# Altium schematic sheet 3-USB_to_JTAG.schdoc(3-USB_to_JTAG)
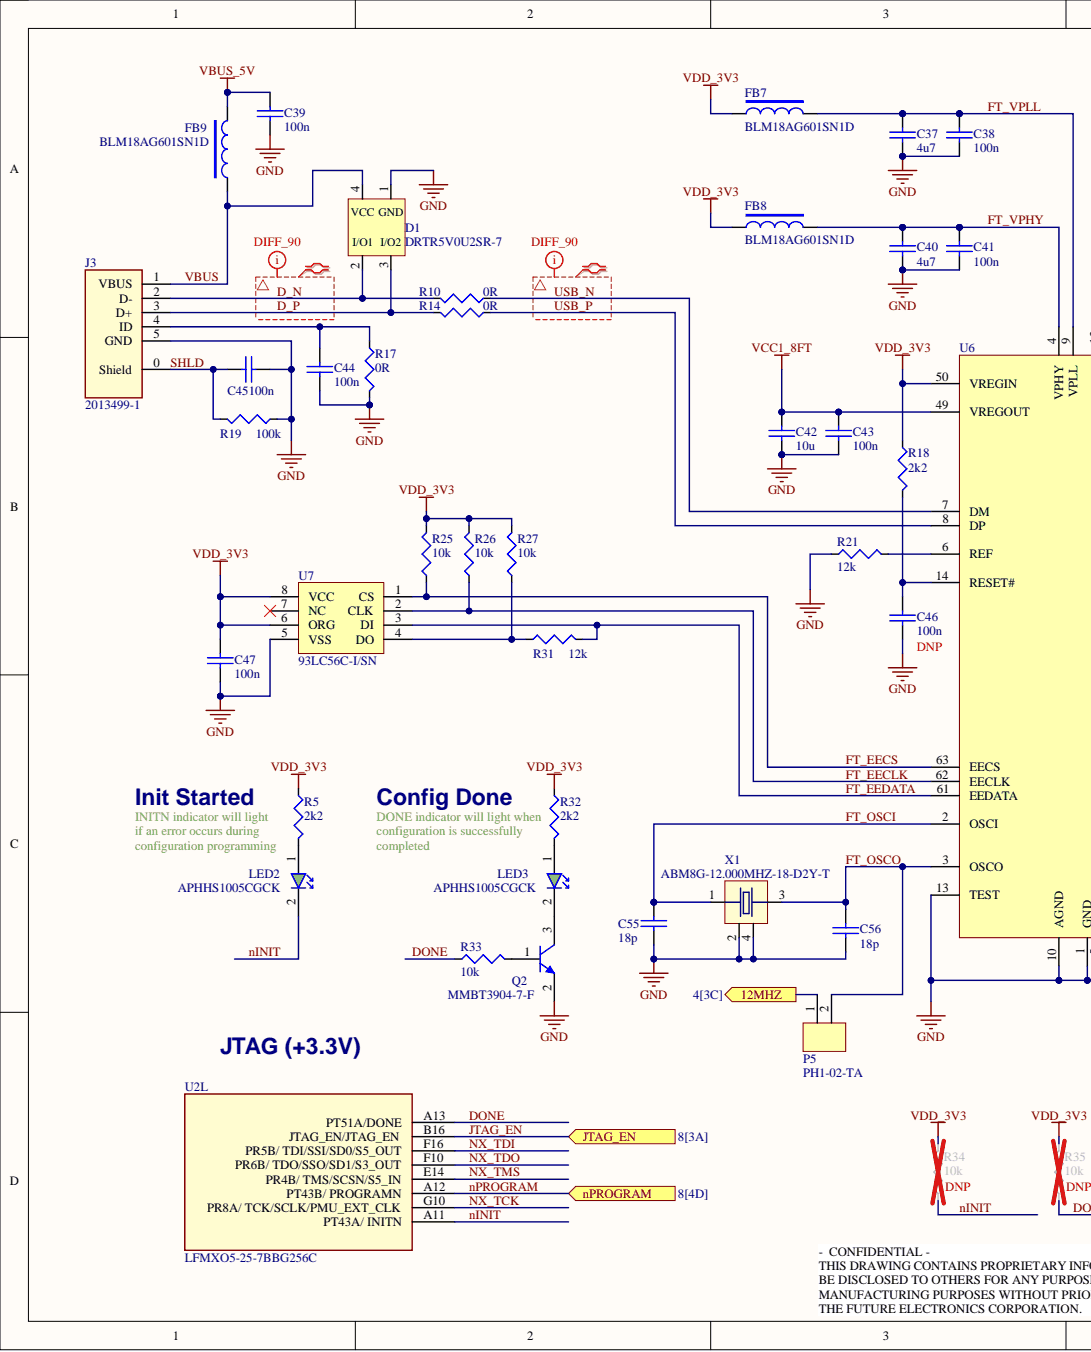
[diagram p0: 3-USB_to_JTAG.schdoc, left]
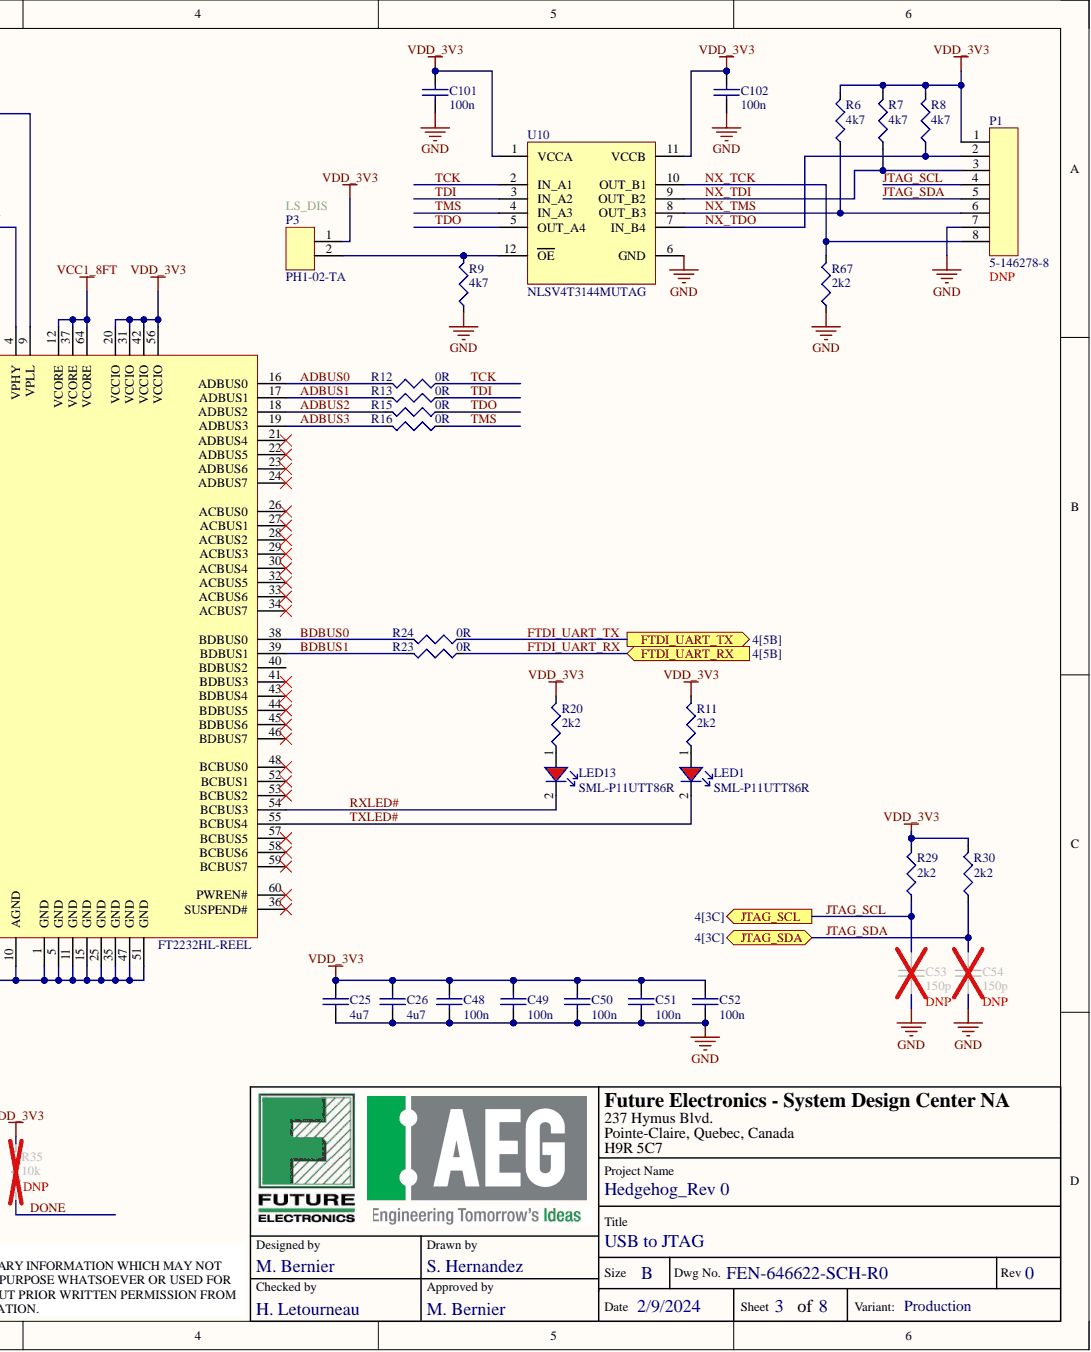
[diagram p1: 3-USB_to_JTAG.schdoc, right]

## Parts
C25  value 4u7  [0805]  (hidden comment: C-4.7uF-10V-Cer-0805)
C26  value 4u7  [0805]  (hidden comment: C-4.7uF-10V-Cer-0805)
C37  value 4u7  [0805]  (hidden comment: C-4.7uF-10V-Cer-0805)
C38  value 100n  [0402]  (hidden comment: C-100nF-50V-Cer-0402)
C39  value 100n  [0402]  (hidden comment: C-100nF-50V-Cer-0402)
C40  value 4u7  [0805]  (hidden comment: C-4.7uF-10V-Cer-0805)
C41  value 100n  [0402]  (hidden comment: C-100nF-50V-Cer-0402)
C42  value 10u  [1206]  (hidden comment: C-10uF-50V-Cer-1206_A)
C43  value 100n  [0402]  (hidden comment: C-100nF-50V-Cer-0402)
C44  value 100n  [0402]  (hidden comment: C-100nF-50V-Cer-0402)
C45  value 100n  [0402]  (hidden comment: C-100nF-50V-Cer-0402)
C46  value 100n  [0402]  (hidden comment: C-100nF-50V-Cer-0402)
C47  value 100n  [0402]  (hidden comment: C-100nF-50V-Cer-0402)
C48  value 100n  [0402]  (hidden comment: C-100nF-50V-Cer-0402)
C49  value 100n  [0402]  (hidden comment: C-100nF-50V-Cer-0402)
C50  value 100n  [0402]  (hidden comment: C-100nF-50V-Cer-0402)
C51  value 100n  [0402]  (hidden comment: C-100nF-50V-Cer-0402)
C52  value 100n  [0402]  (hidden comment: C-100nF-50V-Cer-0402)
C53  value 150p  [0402]  (hidden comment: C-150pF-25V-Cer-0402)
C54  value 150p  [0402]  (hidden comment: C-150pF-25V-Cer-0402)
C55  value 18p  [0402]  (hidden comment: C-18pF-25V-Cer-0402)
C56  value 18p  [0402]  (hidden comment: C-18pF-25V-Cer-0402)
C101  value 100n  [0402]  (hidden comment: C-100nF-50V-Cer-0402)
C102  value 100n  [0402]  (hidden comment: C-100nF-50V-Cer-0402)
D1  MFR PN: DRTR5V0U2SR-7  [SOT143]  (hidden comment: D-DRTR5V0U2SR)
FB7  MFR PN: BLM18AG601SN1D  [0603]  (hidden comment: FB-600Ohm@100MHz-0603)
FB8  MFR PN: BLM18AG601SN1D  [0603]  (hidden comment: FB-600Ohm@100MHz-0603)
FB9  MFR PN: BLM18AG601SN1D  [0603]  (hidden comment: FB-600Ohm@100MHz-0603)
J3  Future PN (TR): 2013499-1  (hidden comment: J-2013499-1)
LED1  Future PN (TR): SML-P11UTT86R  [0402_LED_RED]  (hidden comment: LED-SML-P11UTT86R)
LED2  Future PN (TR): APHHS1005CGCK  [0402-Kingbright]  (hidden comment: LED-APHHS1005CGCK)
LED3  Future PN (TR): APHHS1005CGCK  [0402-Kingbright]  (hidden comment: LED-APHHS1005CGCK)
LED13  Future PN (TR): SML-P11UTT86R  [0402_LED_RED]  (hidden comment: LED-SML-P11UTT86R)
P1  P-5-146278-8  MFR PN: 5-146278-8  [HDR100-8PIN-TH]
P3  MFR PN: PH1-02-TA  [HDR100-2PIN-TH]  (hidden comment: P-PH1-02-TA)
P5  MFR PN: PH1-02-TA  [HDR100-2PIN-TH]  (hidden comment: P-PH1-02-TA)
Q2  Future PN (TR): MMBT3904-7-F  [SOT23]  (hidden comment: Q-MMBT3904-7-F)
R5  value 2k2  [0402]  (hidden comment: R-2.2k-63mW-0402)
R6  value 4k7  [0402]  (hidden comment: R-4.7k-63mW-0402)
R7  value 4k7  [0402]  (hidden comment: R-4.7k-63mW-0402)
R8  value 4k7  [0402]  (hidden comment: R-4.7k-63mW-0402)
R9  value 4k7  [0402]  (hidden comment: R-4.7k-63mW-0402)
R10  value 0R  [0402]  (hidden comment: R-0R-63mW-0402)
R11  value 2k2  [0402]  (hidden comment: R-2.2k-63mW-0402)
R12  value 0R  [0402]  (hidden comment: R-0R-63mW-0402)
R13  value 0R  [0402]  (hidden comment: R-0R-63mW-0402)
R14  value 0R  [0402]  (hidden comment: R-0R-63mW-0402)
R15  value 0R  [0402]  (hidden comment: R-0R-63mW-0402)
R16  value 0R  [0402]  (hidden comment: R-0R-63mW-0402)
R17  value 0R  [0402]  (hidden comment: R-0R-63mW-0402)
R18  value 2k2  [0402]  (hidden comment: R-2.2k-63mW-0402)
R19  value 100k  [0402]  (hidden comment: R-100k-63mW-0402)
R20  value 2k2  [0402]  (hidden comment: R-2.2k-63mW-0402)
R21  value 12k  [0402]  (hidden comment: R-12k-63mW-0402)
R23  value 0R  [0402]  (hidden comment: R-0R-63mW-0402)
R24  value 0R  [0402]  (hidden comment: R-0R-63mW-0402)
R25  value 10k  [0402]  (hidden comment: R-10k-63mW-0402)
R26  value 10k  [0402]  (hidden comment: R-10k-63mW-0402)
R27  value 10k  [0402]  (hidden comment: R-10k-63mW-0402)
R29  value 2k2  [0402]  (hidden comment: R-2.2k-63mW-0402)
R30  value 2k2  [0402]  (hidden comment: R-2.2k-63mW-0402)
R31  value 12k  [0402]  (hidden comment: R-12k-63mW-0402)
R32  value 2k2  [0402]  (hidden comment: R-2.2k-63mW-0402)
R33  value 10k  [0402]  (hidden comment: R-10k-63mW-0402)
R34  value 10k  [0402]  (hidden comment: R-10k-63mW-0402)
R35  value 10k  [0402]  (hidden comment: R-10k-63mW-0402)
R67  value 2k2  [0402]  (hidden comment: R-2.2k-63mW-0402)
U2  MFR PN: LFMXO5-25-7BBG256C  [BBG256_LATTICE]  (hidden comment: U-LFMXO5-25-7BBG256C)  (part L)
U6  MFR PN: FT2232HL-REEL  [LQFP64_M]  (hidden comment: U-FT2232HL-REEL)
U7  MFR PN: 93LC56C-I/SN  [SO8_MICROCHIP]  (hidden comment: U-93LC56C-I/SN)
U10  MFR PN: NLSV4T3144MUTAG  [UQFN12]  (hidden comment: U-NLSV4T3144MUTAG)
X1  MFR PN: ABM8G-12.000MHZ-18-D2Y-T  [ABM8]  (hidden comment: X-ABM8G-12.000MHZ-18-D2Y-T)

## Ports
12MHZ, FTDI_UART_RX, FTDI_UART_TX, JTAG_EN, JTAG_SCL, JTAG_SDA, nPROGRAM

## Nets
GND: C25.2 C26.2 C37.2 C38.2 C39.2 C40.2 C41.2 C42.2 C43.2 C44.2 C45.2 C46.2 C47.2 C48.2 C49.2 C50.2 C51.2 C52.2 C53.2 C54.2 C55.2 C56.2 C101.2 C102.2 D1.1 J3.5(GND) P1.7 Q2.2 R9.2 R17.2 R19.1 R21.1 R67.2 U6.1(GND) U6.5(GND) U6.10(AGND) U6.11(GND) U6.13(TEST) U6.15(GND) U6.25(GND) U6.35(GND) U6.47(GND) U6.51(GND) U7.5(VSS) U10.6(GND) X1.2 X1.4
VBUS_5V: C39.1 FB9.2
VCC1_8FT: C42.1 C43.1 U6.12(VCORE) U6.37(VCORE) U6.49(VREGOUT) U6.64(VCORE)
VDD_3V3: C25.1 C26.1 C47.1 C48.1 C49.1 C50.1 C51.1 C52.1 C101.1 C102.1 FB7.1 FB8.1 P1.1 P3.1 R5.1 R6.1 R7.1 R8.1 R11.1 R18.1 R20.1 R25.2 R26.2 R27.2 R29.1 R30.1 R32.1 R34.2 R35.2 U6.20(VCCIO) U6.31(VCCIO) U6.42(VCCIO) U6.50(VREGIN) U6.56(VCCIO) U7.6(ORG) U7.8(VCC) U10.1(VCCA) U10.11(VCCB)
12MHZ: P5.1 ; port 12MHZ
ADBUS0: R12.2 U6.16(ADBUS0)
ADBUS1: R13.2 U6.17(ADBUS1)
ADBUS2: R15.2 U6.18(ADBUS2)
ADBUS3: R16.2 U6.19(ADBUS3)
BDBUS0: R24.2 U6.38(BDBUS0)
BDBUS1: R23.2 U6.39(BDBUS1)
D_N: D1.2 J3.2(D-) R10.2
D_P: D1.3 J3.3(D+) R14.2
DONE: R33.1 R35.1 U2.A13(PT51A/DONE)
FT_EECLK: R26.1 U6.62(EECLK) U7.2(CLK)
FT_EECS: R25.1 U6.63(EECS) U7.1(CS)
FT_EEDATA: R31.2 U6.61(EEDATA) U7.3(DI)
FT_OSCI: C55.1 U6.2(OSCI) X1.1
FT_OSCO: C56.1 P5.2 U6.3(OSCO) X1.3
FT_VPHY: C40.1 C41.1 FB8.2 U6.4(VPHY)
FT_VPLL: C37.1 C38.1 FB7.2 U6.9(VPLL)
FTDI_UART_RX: R23.1 ; port FTDI_UART_RX
FTDI_UART_TX: R24.1 ; port FTDI_UART_TX
JTAG_EN: U2.B16(JTAG_EN/JTAG_EN) ; port JTAG_EN
JTAG_SCL: C53.1 P1.4 R29.2 ; port JTAG_SCL
JTAG_SDA: C54.1 P1.5 R30.2 ; port JTAG_SDA
nINIT: LED2.2 R34.1 U2.A11(PT43A/_INITN)
nPROGRAM: U2.A12(PT43B/_PROGRAMN) ; port nPROGRAM
NX_TCK: P1.8 R67.1 U2.G10(PR8A/_TCK/SCLK/PMU_EXT_CLK) U10.10(OUT_B1)
NX_TDI: P1.3 R7.2 U2.F16(PR5B/_TDI/SSI/SD0/S5_OUT) U10.9(OUT_B2)
NX_TDO: P1.2 R8.2 U2.F10(PR6B/_TDO/SSO/SD1/S3_OUT) U10.7(IN_B4)
NX_TMS: P1.6 R6.2 U2.E14(PR4B/_TMS/SCSN/S5_IN) U10.8(OUT_B3)
RXLED#: LED13.2 U6.54(BCBUS3)
SHLD: C45.1 J3.0(Shield) R19.2
TCK: R12.1 U10.2(IN_A1)
TDI: R13.1 U10.3(IN_A2)
TDO: R15.1 U10.5(OUT_A4)
TMS: R16.1 U10.4(IN_A3)
TXLED#: LED1.2 U6.55(BCBUS4)
USB_N: R10.1 U6.7(DM)
USB_P: R14.1 U6.8(DP)
VBUS: D1.4 FB9.1 J3.1(VBUS)
NetC44_1: C44.1 J3.4(ID) R17.1
NetC46_1: C46.1 R18.2 U6.14(RESET#)
NetLED1_1: LED1.1 R11.2
NetLED2_1: LED2.1 R5.2
NetLED3_1: LED3.1 R32.2
NetLED3_2: LED3.2 Q2.3
NetLED13_1: LED13.1 R20.2
NetP3_2: P3.2 R9.1 U10.12(OE)
NetQ2_1: Q2.1 R33.2
NetR21_2: R21.2 U6.6(REF)
NetR27_1: R27.1 R31.1 U7.4(DO)

Not connected: U6 21(ADBUS4) 22(ADBUS5) 23(ADBUS6) 24(ADBUS7) 26(ACBUS0) 27(ACBUS1) 28(ACBUS2) 29(ACBUS3) 30(ACBUS4) 32(ACBUS5) 33(ACBUS6) 34(ACBUS7) 36(SUSPEND#) 40(BDBUS2) 41(BDBUS3) 43(BDBUS4) 44(BDBUS5) 45(BDBUS6) 46(BDBUS7) 48(BCBUS0) 52(BCBUS1) 53(BCBUS2) 57(BCBUS5) 58(BCBUS6) 59(BCBUS7) 60(PWREN#); U7 7(NC)

## Library data of the parts
C25 C26 C37 C40 = C-4.7uF-10V-Cer-0805: Cap 0805 SM X7R 10V 10%; MFR: TAIYO YUDEN; MFR PN: LMK212B7475KG-T; Future PN (CT): LMK212B7475KGTR-CT; Future PN (TR): LMK212B7475KGTR; Rating: 10V 10%; Component Kind: Standard; ComponentLink1Description: Datasheet; ComponentLink1URL: https://www.yuden.co.jp/productdata/catalog/mlcc01_e.pdf; Library Name: Future_Capacitors_V1.SCHLIB; Verified: R.Brack_2020-Feb-03
C38 C39 C41 C43-C52 C101 C102 = C-100nF-50V-Cer-0402: Cap 0402 SM X7R 50V 10%; MFR: TDK; MFR PN: C1005X7R1H104K050BB; Future PN (CT): 04025C104KATN-CT; Future PN (TR): 04025C104KATN; Rating: 50V 10%; Component Kind: Standard; ComponentLink1Description: Datasheet; ComponentLink1URL: http://images.ihscontent.net/vipimages/VipMasterIC/IC/TDKC/TDKC-S-A0000443042/TDKC-S-A0000443042-1.pdf; Library Name: Future_Capacitors_V1.SCHLIB; Verified: AD042616
C42 = C-10uF-50V-Cer-1206_A: Cap 1206 SM X7R 50V 10%; MFR: SAMSUNG; MFR PN: CL31B106KBHNNNE; Future PN (CT): TBD; Future PN (TR): 12065C106KATN; Rating: 50V 10%; Component Kind: Standard; ComponentLink1Description: Datasheet; ComponentLink1URL: http://www.samsungsem.com/kr/support/product-search/mlcc/__icsFiles/afieldfile/2019/06/18/CL31B106KBHNNNE-19.pdf; Library Name: Future_Capacitors_V1.SCHLIB; Verified: AD112817
C53 C54 = C-150pF-25V-Cer-0402: Cap 0402 SM NPO 25V 5%; MFR: YAGEO; MFR PN: CC0402JRNPO8BN151; Future PN (CT): 04023A151JATN-CT; Future PN (TR): 04023A151JATN; Rating: 25V 5%; Component Kind: Standard; ComponentLink1Description: Datasheet; ComponentLink1URL: http://www.yageo.com/documents/recent/UPY-GP_NP0_16V-to-50V_10.pdf; Library Name: Future_Capacitors_V1.SCHLIB; Verified: AD030615
C55 C56 = C-18pF-25V-Cer-0402: Cap 0402 SM COG 25V 5%; MFR: AVX; MFR PN: 04023A180JAT2A; Future PN (CT): 04023A180JATN-CT; Future PN (TR): 04023A180JATN; Rating: 25V 5%; Component Kind: Standard; ComponentLink1Description: Datasheet; ComponentLink1URL: http://www.avx.com/docs/Catalogs/ccog.pdf; Library Name: Future_Capacitors_V1.SCHLIB; Verified: AD030615
D1 = D-DRTR5V0U2SR_small: Protection TVS 2ch SOT143 SM 6Vbr; MFR: DIODES; Future PN (CT): TBD; Future PN (TR): DRTR5V0U2SRTR; VModel: MMDDYY; Component Kind: Standard; ComponentLink1Description: Datasheet; ComponentLink1URL: https://www.diodes.com/assets/Datasheets/DRTR5V0U2SR.pdf; Rating: 6Vbr; Created by: CZ120120; Library Name: Hedgehog_Rev0.SchLib
FB7-FB9 = FB-600Ohm@100MHz-0603: Inductor EMI Suppression Filters 0603 SM 500mArt 600Ohm@100MHz; MFR: MURATA; Future PN (CT): BLM11A601SPT-CT; Future PN (TR): BLM11A601SPT; VModel: MMDDYY; Component Kind: Standard; ComponentLink1Description: Datasheet; ComponentLink1URL: https://www.murata.com/en-us/products/productdata/8796738650142/ENFA0003.pdf; Rating: 500mArt 600Ohm@100MHz; Verified: TBD; Library Name: Hedgehog_Rev0.SchLib
J3 = J-2013499-1: Connector USB 2.0 Micro B RA Top Assemb SM 1x5pos; MFR: TE; Future PN (CT): TBD; Rating: 5Pos; VModel: MMDDYY; Component Kind: Standard; ComponentLink1Description: Datasheet; ComponentLink1URL: https://www.te.com/usa-en/product-2013499-1.datasheet.pdf; MFR PN: 2013499-1; Created by: CZ081922; Library Name: Hedgehog_Rev0.SchLib
LED1 LED13 = LED-SML-P11UTT86R: LED Std 0402 SM 1.8Vfw 1mA 2.5mcd 621nm Red; MFR: ROHM; Apr'd: YES; Future PN (CT): TBD; Component Kind: Standard; ComponentLink1Description: Datasheet; ComponentLink1URL: http://www.rohm.com/web/global/datasheet/SML-P11MT(R); Library Name: Future_Opto_V1.SchLib; MFR PN: SML-P11UTT86R; Rating: 1.8Vfw 1mA; Value: RED; Verified: AD121516
LED2 LED3 = LED-APHHS1005CGCK: LED Green AlGaInP 0402 SM 2.1-2.5Vf 30mA 574nm-pk 570nm-d 20mcd; MFR: KINGBRIGHT; Future PN (CT): APHHS1005CGCK-CT; Rating: 2.1Vf 20mA 75mW 570nm 50mcd; Component Kind: Standard; ComponentLink1Description: Datasheet; ComponentLink1URL: http://www.kingbrightusa.com/images/catalog/SPEC/APHHS1005CGCK.pdf; Library Name: Future_Opto_V1.SchLib; MFR PN: APHHS1005CGCK; Value: GREEN; Created by: R.Brack_2020-Mar-02
P1 = P-5-146278-8: Connector 1Row Vert TH 1x8 2.54mm Pin Header; MFR: TE; Future PN (CT): NSH-08SB-S2-T; Future PN (TR): TBD; VModel: MMDDYY; Component Kind: Standard; ComponentLink1Description: Datasheet; ComponentLink1URL: http://www.te.com/commerce/DocumentDelivery/DDEController?Action=showdoc&DocId=Customer+Drawing%7F146278%7FH%7Fpdf%7FEnglish%7FENG_CD_146278_H_146278_1_c.dwg.pdf%7F5-146278-8; Library Name: Future_Connectors_V1.SCHLIB; Rating: 1x8 2.54mm; Verified: AD081815
P3 P5 = P-PH1-02-TA: Connector 1Row Vert TH 1x2 2.54mm Pin Header; MFR: ADAMTECH; Future PN (CT): NSH-02SB-S2-T; Future PN (TR): TBD; VModel: MMDDYY; Component Kind: Standard; ComponentLink1Description: Datasheet; ComponentLink1URL: http://images.ihscontent.net/vipimages/VipMasterIC/IC/ADMT/ADMTS02206/ADMTS02206-1.pdf; Library Name: Future_Connectors_V1.SCHLIB; Rating: 1x2 2.54mm; Verified: AD072915
Q2 = Q-MMBT3904-7-F: Transistor NPN SOT23 SM 350mW 40Vce 200mA; MFR: DIODES; Future PN (CT): TBD; VModel: MMDDYY; Component Kind: Standard; ComponentLink1Description: Datasheet; ComponentLink1URL: https://www.diodes.com/assets/Datasheets/ds30036.pdf; Library Name: Future_Semiconductors_V1.SchLib; MFR PN: MMBT3904-7-F; Rating: 40Vce 200mA; Verified: CZ040919
R5 R11 R18 R20 R29 R30 R32 R67 = R-2.2k-63mW-0402: Res 0402 SM 63mW 1% 100ppm; MFR: YAGEO; MFR PN: RC0402FR-072K2L; Future PN (CT): CR0402-2201FTR-CT; Future PN (TR): CR0402-2201FTR; Rating: 63mW 1%; Component Kind: Standard; ComponentLink1Description: Datasheet; ComponentLink1URL: https://www.yageo.com/upload/media/product/products/datasheet/rchip/PYu-RC_Group_51_RoHS_L_10.pdf; Library Name: Future_Resistors_V1.SCHLIB
R6-R9 = R-4.7k-63mW-0402: Res 0402 SM 63mW 1% 100ppm; MFR: YAGEO; MFR PN: RC0402FR-074K7L; Future PN (CT): CR0402-4701FTR-CT; Future PN (TR): CR0402-4701FTR; Rating: 63mW 1%; Component Kind: Standard; ComponentLink1Description: Datasheet; ComponentLink1URL: http://www.yageo.com/documents/recent/PYu-RC0402_51_RoHS_L_6.pdf; Library Name: Future_Resistors_V1.SCHLIB
R10 R12-R17 R23 R24 = R-0R-63mW-0402: Res 0402 SM 63mW; MFR: YAGEO; MFR PN: RC0402JR-070RL; Future PN (CT): CR0402-0000JTR-CT; Future PN (TR): CR0402-0000JTR; Component Kind: Standard; ComponentLink1Description: Datasheet; ComponentLink1URL: https://www.yageo.com/upload/media/product/products/datasheet/rchip/PYu-RC_Group_51_RoHS_L_10.pdf; Library Name: Future_Resistors_V1.SCHLIB; Rating: 63mW; Verified: AD062917
R19 = R-100k-63mW-0402: Res 0402 SM 63mW 1% 100ppm; MFR: YAGEO; MFR PN: RC0402FR-07100KL; Future PN (CT): CR0402-1003FTR-CT; Future PN (TR): CR0402-1003FTR; Rating: 63mW 1%; Component Kind: Standard; ComponentLink1Description: Datasheet; ComponentLink1URL: https://www.yageo.com/upload/media/product/products/datasheet/rchip/PYu-RC_Group_51_RoHS_L_10.pdf; Library Name: Future_Resistors_V1.SCHLIB
R21 R31 = R-12k-63mW-0402: Res 0402 SM 63mW 1% 100ppm; MFR: YAGEO; MFR PN: RC0402FR-0712KL; Future PN (CT): CR0402-1202FTR-CT; Future PN (TR): CR0402-1202FTR; Rating: 63mW 1%; Component Kind: Standard; ComponentLink1Description: Datasheet; ComponentLink1URL: http://www.yageo.com/documents/recent/PYu-RC0402_51_RoHS_L_6.pdf; Library Name: Future_Resistors_V1.SCHLIB
R25-R27 R33-R35 = R-10k-63mW-0402: Res 0402 SM 63mW 1% 100ppm; MFR: YAGEO; MFR PN: RC0402FR-0710KL; Future PN (CT): CR0402-1002FTR-CT; Future PN (TR): CR0402-1002FTR; Rating: 63mW 1%; Component Kind: Standard; ComponentLink1Description: Datasheet; ComponentLink1URL: https://www.yageo.com/upload/media/product/products/datasheet/rchip/PYu-RC_Group_51_RoHS_L_10.pdf; Library Name: Future_Resistors_V1.SCHLIB
U2 = U-LFMXO5-25-7BBG256C: FPGA MachXO5-NX BBG256 SM 0.95~1.05Vcore 450MHz; MFR: LATTICE; Future PN (CT): TBD; VModel: MMDDYY; Component Kind: Standard; ComponentLink1Description: Datasheet; ComponentLink1URL: https://www.latticesemi.com/-/media/LatticeSemi/Documents/MachXO5-NX/FPGA-DS-02102-1-0-MachXO5-NX-Family.ashx?document_id=53488; Future PN (TR): TBD; Rating: 0.95~1.05Vcore 450MHz; Verified: TBD; Library Name: Hedgehog_Rev0.SchLib
U6 = U-FT2232HL-REEL: Interface USB to UART/FIFO LQFP64 SM 1.62-1.98Vcore; MFR: FTDI; Future PN (CT): FT2232HL-R-CT; VModel: MMDDYY; Component Kind: Standard; ComponentLink1Description: Datasheet; ComponentLink1URL: http://images.ihscontent.net/vipimages/VipMasterIC/IC/FTDI/FTDIS00081/FTDIS00081-1.pdf; Future PN (TR): FT2232HL-R; Library Name: Future_Semiconductors_V1.SchLib; Rating: 1.62-1.98Vcore; Verified: AD021317
U7 = U-93LC56C-I/SN: Memory EEPROM MicroWire SO8 SM 2.5-5.5Vop 2Kbit 1-3MHz; MFR: MICROCHIP; Apr'd: TBD; Component Kind: Standard; ComponentLink1Description: Datasheet; ComponentLink1URL: https://ww1.microchip.com/downloads/en/DeviceDoc/21794G.pdf; Future PN (CT): TBD; Future PN (TR): TBD; Rating: 2.5-5.5Vop 2Kbit; Verified: TBD; Library Name: Hedgehog_Rev0.SchLib
U10 = U-NLSV4T3144MUTAG: Interface Level Translator 4bit Dual Supply UQFN12 SM 1.6-3.6VccA and VccB; MFR: ON SEMI; Future PN (CT): TBD; VModel: MMDDYY; Component Kind: Standard; ComponentLink1Description: Datasheet; ComponentLink1URL: https://www.onsemi.com/pdf/datasheet/nlsv4t3144-d.pdf; Future PN (TR): TBD; Rating: 1.6-3.6VccA and VccB; Verified: TBD; Library Name: Hedgehog_Rev0.SchLib
X1 = X-ABM8G-12.000MHZ-18-D2Y-T: Timing Crystal ABM8 SM 12.000MHz ±20ppm 18pF; MFR: ABRACON; Future PN (CT): TBD; Future PN (TR): 12.0000M-ABM8GT; VModel: MMDDYY; Component Kind: Standard; ComponentLink1Description: Datasheet; ComponentLink1URL: http://www.abracon.com/Resonators/ABM8G.pdf; Library Name: Future_Semiconductors_V1.SchLib; Rating: ±20ppm 18pF; Value: 12.000MHz; Verified: AD012015
